# Altium schematic sheet SolarSenseBoard.SchDoc(SolarSenseBoard)
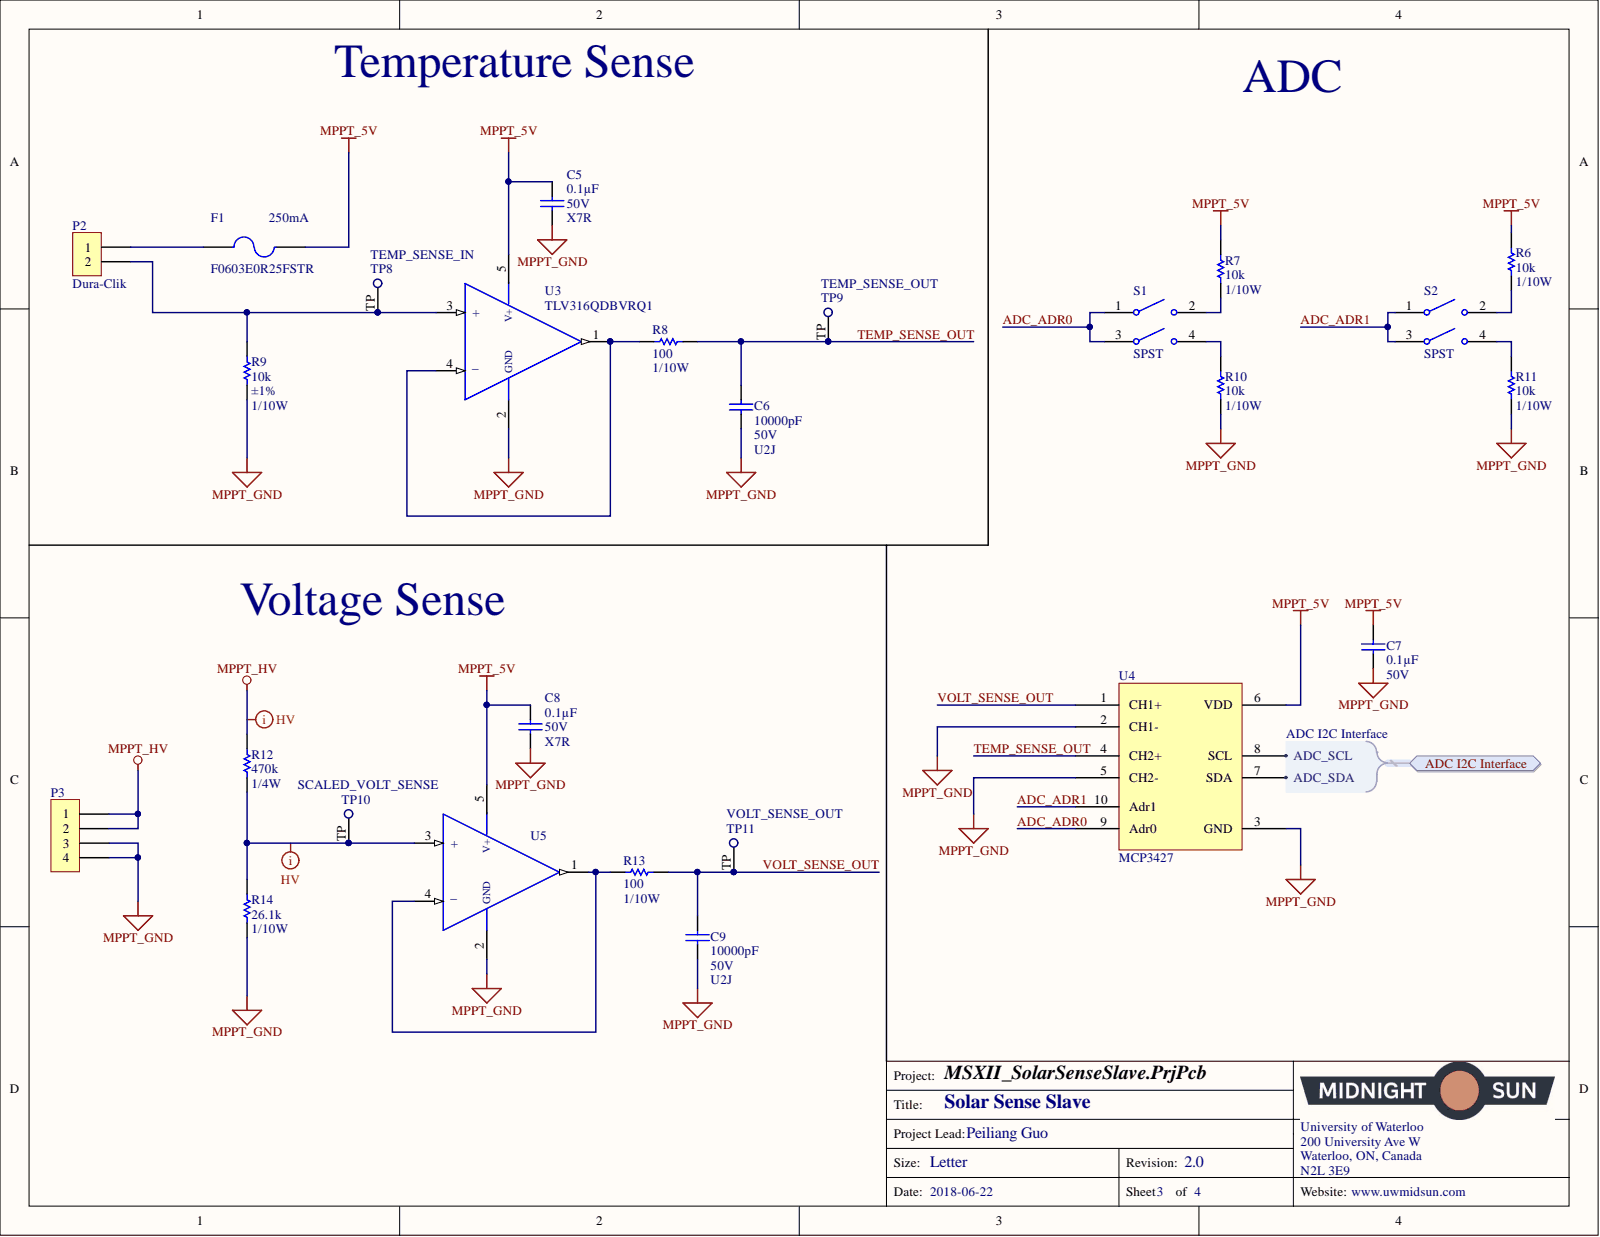
[diagram p0: SolarSenseBoard.SchDoc]

## Parts
C5  Voltage: 50V  Temperature Coefficient: X7R  Capacitance: 0.1µF  [CAP, 0603]  (hidden comment: CAP CER 0.1UF 50V 10% X7R 0603)
C6  Voltage: 50V  Temperature Coefficient: U2J  Capacitance: 10000pF  [CAP, 0603]  (hidden comment: CAP CER 10nF 50V 5% X7R 0603)
C7  Voltage: 50V  Capacitance: 0.1µF  [CAP, 0603]  (hidden comment: CAP CER 0.1UF 50V 10% X7R 0603)
C8  Voltage: 50V  Temperature Coefficient: X7R  Capacitance: 0.1µF  [CAP, 0603]  (hidden comment: CAP CER 0.1UF 50V 10% X7R 0603)
C9  Voltage: 50V  Temperature Coefficient: U2J  Capacitance: 10000pF  [CAP, 0603]  (hidden comment: CAP CER 10nF 50V 5% X7R 0603)
F1  Manufacturer Part Number: F0603E0R25FSTR  Fuse Current: 250mA  [RES, 0603]  (hidden comment: FUSE 250MA 32VDC 0603)
P2  Dura-Clik  [CONN, 2POS Dura-Click Vertical]
P3  CONN 4POS MX150L  [CONN, 4POS MX150L]  (value not drawn)
R6  Resistance: 10k  Power: 1/10W  [RES, 0603]  (hidden comment: RES 10K OHM 1% 1/10W 0603)
R7  Resistance: 10k  Power: 1/10W  [RES, 0603]  (hidden comment: RES 10K OHM 1% 1/10W 0603)
R8  Power: 1/10W  [RES, 0603]  (hidden comment: RES 100 OHM 1% 1/10W 0603)
R9  Tolerance: ±1%  Resistance: 10k  Power: 1/10W  [RES, 0603]  (hidden comment: RES 10K OHM 1% 1/10W 0603)
R10  Resistance: 10k  Power: 1/10W  [RES, 0603]  (hidden comment: RES 10K OHM 1% 1/10W 0603)
R11  Resistance: 10k  Power: 1/10W  [RES, 0603]  (hidden comment: RES 10K OHM 1% 1/10W 0603)
R12  Resistance: 470k  Power: 1/4W  [RES, 0603]  (hidden comment: RES 470K OHM 1% 1/4W 0603)
R13  Power: 1/10W  [RES, 0603]  (hidden comment: RES 100 OHM 1% 1/10W 0603)
R14  Resistance: 26.1k  Power: 1/10W  [RES, 0603]  (hidden comment: RES 26.1K OHM 1% 1/10W 0603)
S1  SPST  [SW SPST 2POS DIP 0.1A 20V]
S2  SPST  [SW SPST 2POS DIP 0.1A 20V]
TP8  TEMP_SENSE_IN  [Test Point]  (no BOM)
TP9  TEMP_SENSE_OUT  [Test Point]
TP10  SCALED_VOLT_SENSE  [Test Point]  (no BOM)
TP11  VOLT_SENSE_OUT  [Test Point]
U3  Manufacturer Part Number 1: TLV316QDBVRQ1  [IC OP AMP GP RR 10MHZ SOT-23-5]  (hidden comment: IC OP AMP GEN PURPOSE RR 10MHZ SOT-23-5)
U4  Base Part Number: MCP3427  [IC ADC MCP3427]  (hidden comment: IC ADC MCP3427 10MSOP)
U5  IC OP AMP GEN PURPOSE RR 10MHZ SOT-23-5  [IC OP AMP GP RR 10MHZ SOT-23-5]  (value not drawn)

## Ports
ADC I2C Interface, ADC I2C Interface.ADC_SCL, ADC I2C Interface.ADC_SDA

## Nets
MPPT_5V: C5.1 C7.1 C8.1 F1.2 R6.1 R7.1 U3.5 U4.6(VDD) U5.5
MPPT_GND: C5.2 C6.2 C7.2 C8.2 C9.2 P3.3 P3.4 R9.2 R10.2 R11.2 R14.2 U3.2 U4.2(CH1-) U4.3(GND) U4.5(CH2-) U5.2
MPPT_HV: P3.1 P3.2 R12.1
ADC_ADR0: S1.1 S1.3 U4.9(Adr0)
ADC_ADR1: S2.1 S2.3 U4.10(Adr1)
TEMP_SENSE_OUT: C6.1 R8.2 TP9.TP U4.4(CH2+)
VOLT_SENSE_OUT: C9.1 R13.2 TP11.TP U4.1(CH1+)
NetF1_1: F1.1 P2.1
NetP2_2: P2.2 R9.1 TP8.TP U3.3(+)
NetR6_2: R6.2 S2.2
NetR7_2: R7.2 S1.2
NetR8_1: R8.1 U3.1 U3.4(_)
NetR10_1: R10.1 S1.4
NetR11_1: R11.1 S2.4
NetR12_2: R12.2 R14.1 TP10.TP U5.3(+)
NetR13_1: R13.1 U5.1 U5.4
NetU4_7: U4.7(SDA) ; port ADC I2C Interface
NetU4_8: U4.8(SCL) ; port ADC I2C Interface

## Library data of the parts
C5 C7 C8 = CAP CER 0.1UF 50V 10% X7R 0603: 0.10µF ±10% 50V Ceramic Capacitor X7R 0603 (1608 Metric); Manufacturer Part Number 1: 06035C104KAT2A; Manufacturer 1: AVX Corporation; Tolerance: ±10%; Thickness (Max): 0.035" (0.90mm); Supplier Part Number 1: 478-5052-1-ND; Supplier 1: Digi-Key; Size / Dimension: 0.063" L x 0.032" W (1.60mm x 0.81mm); Ratings: -; Part Status: Active; Packaging: Cut Tape (CT); Package / Case: 0603 (1608 Metric); Operating Temperature: -55°C ~ 125°C; Mounting Type: Surface Mount, MLCC; Lead Style: -; Lead Spacing: -; Height - Seated (Max): -; ComponentLink1URL: http://datasheets.avx.com/X7RDielectric.pdf; ComponentLink1Description: http://datasheets.avx.com/X7RDielectric.pdf; Component Kind: Standard; Library Name: Schematic Diagrams.SchLib
  C7: Temperature Coefficient: X7R
C6 C9 = CAP CER 10nF 50V 5% X7R 0603: 10000pF ±5% 50V Ceramic Capacitor U2J 0603 (1608 Metric); Manufacturer Part Number 1: C0603C103J5JAC7867; Manufacturer 1: KEMET; Tolerance: ±5%; Thickness (Max): 0.034" (0.87mm); Supplier Part Number 1: 399-13384-1-ND; Supplier 1: Digi-Key; Size / Dimension: 0.063" L x 0.032" W (1.60mm x 0.80mm); Ratings: -; Part Status: Active; Packaging: Cut Tape (CT); Package / Case: 0603 (1608 Metric); Operating Temperature: -55°C ~ 125°C; Mounting Type: Surface Mount, MLCC; Lead Style: -; Lead Spacing: -; Height - Seated (Max): -; Failure Rate: -; ComponentLink1URL: http://www.kemet.com/docfinder?Partnumber=C0603C103J5JAC7867; ComponentLink1Description: http://www.kemet.com/docfinder?Partnumber=C0603C103J5JAC7867; Component Kind: Standard; Library Name: Schematic Diagrams.SchLib
F1 = FUSE 250MA 32VDC 0603: Manufacturer: Kyocera AVX; Voltage Rating (DC): 32V; Resistance: 650mR; Fuse Type: Board Mount (Cartridge Style Excluded); Approvals: UL; Width: 0.81mm; Voltage Rating (AC): 32V; Voltage Rating: 32V; Terminal Material: Nickel; Supplier Part Number 1: 478-2858-1-ND; Supplier 1: Digi-Key; Stock 1: 48569; REACH SVHC: No SVHC; Radiation Hardening: No; Pricing 1: 1=0.41, 5=0.394, 10=0.381, 50=0.3206, 100=0.2662, 250=0.242, 500=0.2057, 1000=0.1815 (USD); Packaging: Tape and Reel; Package Quantity: 1; Number of Pins: 2; Mount: Surface Mount; Min Operating Temperature: -55°C; Max Voltage Rating (DC): 32V; Max Operating Temperature: 125°C; Length: 1.6mm; Lead Free: Lead Free; Height: 0.63mm; Depth: 0.81mm; DC Cold Resistance: 650mR; Current Rating: 250mA; Contact Plating: Tin,Matte; Composition: Thin Film; ComponentLink5URL: https://datasheet.ciiva.com/301/accug2-301327.pdf; ComponentLink5Description: https://datasheet.ciiva.com/301/accug2-301327.pdf; ComponentLink4URL: https://datasheet.ciiva.com/28473/1819437-28473548.pdf; ComponentLink4Description: https://datasheet.ciiva.com/28473/1819437-28473548.pdf; ComponentLink3URL: https://datasheet.ciiva.com/7650/accugrd-7650774.pdf; ComponentLink3Description: https://datasheet.ciiva.com/7650/accugrd-7650774.pdf; ComponentLink2URL: https://datasheet.ciiva.com/301/accug2-301329.pdf; ComponentLink2Description: https://datasheet.ciiva.com/301/accug2-301329.pdf; ComponentLink1URL: https://datasheet.ciiva.com/301/accug2-301328.pdf; ComponentLink1Description: https://datasheet.ciiva.com/301/accug2-301328.pdf; Component Kind: Standard; Case Code (Metric): 1608; Case Code (Imperial): 0603; Case/Package: 0603; Library Name: Schematic Diagrams.SchLib
P2 = CONN 2POS DURA-CLIK 0.079" VERT: 2 Positions Header, Shrouded Connector 0.079" (2.00mm) Surface Mount, Vertical Tin; Manufacturer Part Number: 5600200220; Manufacturer: Molex, LLC; Style: Board to Cable/Wire; Shrouding: Shrouded - 4 Wall; Number of Positions Loaded: All; Number of Positions: 2; Mated Stacking Heights: 9.3mm; Voltage Rating: -; Termination: Solder; Supplier Part Number 1: WM10862CT-ND; Supplier 1: Digi-Key; Stock 1: 11912; Row Spacing - Mating: -; RoHS: RoHS Compliant; Pricing 1: 1=1.16, 10=1.012, 25=0.952, 50=0.9122, 100=0.8727, 250=0.79336 (CAD); Pitch - Mating: 0.079" (2.00mm); Part Status: Active; Packaging: Cut Tape (CT); Overall Contact Length: -; Operating Temperature: -; Number of Rows: 1; Mounting Type: Surface Mount; Material Flammability Rating: -; Insulation Material: Polyamide (PA), Nylon; Insulation Height: 0.315" (8.00mm); Insulation Color: Natural; Ingress Protection: -; Features: Solder Retention; Fastening Type: Latch Lock; Current Rating: -; Contact Type: Male Pin; Contact Shape: Square; Contact Material: Copper Alloy; Contact Length - Post: -; Contact Length - Mating: -; Contact Finish Thickness - Post: -; Contact Finish Thickness - Mating: -; Contact Finish - Post: Tin; Contact Finish - Mating: Tin; Connector Type: Header; ComponentLink1URL: http://www.molex.com/pdm_docs/sd/5600200220_sd.pdf; ComponentLink1Description: http://www.molex.com/pdm_docs/sd/5600200220_sd.pdf; Component Kind: Standard; Category: Connectors, Interconnects; Applications: Automotive, Industrial; Library Name: Schematic Diagrams.SchLib
P3 = CONN 4POS MX150L: Connector Header Panel Mount, Through Hole, Right Angle 4 position 0.230" (5.84mm); Component Kind: Standard; Library Name: Schematic Diagrams.SchLib
R6 R7 R9-R11 = RES 10K OHM 1% 1/10W 0603: RES SMD 10K OHM 1% 1/10W 0603; Manufacturer Part Number 1: RC0603FR-0710KL; Manufacturer 1: Yageo; Temperature Coefficient: ±100ppm/°C; Supplier Part Number 1: 311-10.0KHRCT-ND; Supplier Device Package: 0603; Supplier 1: Digi-Key; Size / Dimension: 0.063" L x 0.031" W (1.60mm x 0.80mm); Part Status: Active; Packaging: Cut Tape (CT); Package / Case: 0603 (1608 Metric); Operating Temperature: -55°C ~ 155°C; Height - Seated (Max): 0.022" (0.55mm); Failure Rate: -; Composition: Thick Film; ComponentLink1URL: http://www.yageo.com/documents/recent/PYu-RC_Group_51_RoHS_L_7.pdf; ComponentLink1Description: http://www.yageo.com/documents/recent/PYu-RC_Group_51_RoHS_L_7.pdf; Component Kind: Standard; Library Name: Schematic Diagrams.SchLib
  R6 R7 R10 R11: Tolerance: ±1%
R8 R13 = RES 100 OHM 1% 1/10W 0603: 100 Ohm ±1% 0.1W, 1/10W Chip Resistor 0603 (1608 Metric) Moisture Resistant Thick Film; Manufacturer Part Number 1: RC0603FR-07100RL; Manufacturer 1: Yageo; Temperature Coefficient: ±100ppm/°C; Resistance: 100; Tolerance: ±1%; Supplier Part Number 1: 311-100HRCT-ND; Supplier Device Package: 0603; Supplier 1: Digi-Key; Size / Dimension: 0.063" L x 0.031" W (1.60mm x 0.80mm); Part Status: Active; Packaging: Cut Tape (CT); Package / Case: 0603 (1608 Metric); Operating Temperature: -55°C ~ 155°C; Height - Seated (Max): 0.022" (0.55mm); Failure Rate: -; Composition: Thick Film; ComponentLink1URL: http://www.yageo.com/documents/recent/PYu-RC_Group_51_RoHS_L_7.pdf; ComponentLink1Description: http://www.yageo.com/documents/recent/PYu-RC_Group_51_RoHS_L_7.pdf; Component Kind: Standard; Library Name: Schematic Diagrams.SchLib
R12 = RES 470K OHM 1% 1/4W 0603: RES SMD 470K OHM 1% 1/4W 0603; Manufacturer Part Number 1: ERJ-PA3F4703V; Manufacturer 1: Panasonic Electronic Components; Temperature Coefficient: ±100ppm/°C; Tolerance: ±1%; Supplier Part Number 1: P470KBYCT-ND; Supplier Device Package: 0603; Supplier 1: Digi-Key; Size / Dimension: 0.063" L x 0.031" W (1.60mm x 0.80mm); Part Status: Active; Packaging: Cut Tape (CT); Package / Case: 0603 (1608 Metric); Operating Temperature: -55°C ~ 155°C; Height - Seated (Max): 0.022" (0.55mm); Failure Rate: -; Composition: Thick Film; ComponentLink1URL: https://industrial.panasonic.com/cdbs/www-data/pdf/RDO0000/AOA0000C291.pdf; ComponentLink1Description: https://industrial.panasonic.com/cdbs/www-data/pdf/RDO0000/AOA0000C291.pdf; Component Kind: Standard; Library Name: Schematic Diagrams.SchLib
R14 = RES 26.1K OHM 1% 1/10W 0603: RES SMD 26.1K OHM 1% 1/10W 0603; Manufacturer Part Number 1: RC0603FR-0726K1L; Manufacturer 1: Yageo; Temperature Coefficient: ±100ppm/°C; Tolerance: ±1%; Supplier Part Number 1: 311-26.1KHRCT-ND; Supplier Device Package: 0603; Supplier 1: Digi-Key; Size / Dimension: 0.063" L x 0.031" W (1.60mm x 0.80mm); Part Status: Active; Packaging: Cut Tape (CT); Package / Case: 0603 (1608 Metric); Operating Temperature: -55°C ~ 155°C; Height - Seated (Max): 0.022" (0.55mm); Failure Rate: -; Composition: Thick Film; ComponentLink1URL: http://www.yageo.com/documents/recent/PYu-RC_Group_51_RoHS_L_7.pdf; ComponentLink1Description: http://www.yageo.com/documents/recent/PYu-RC_Group_51_RoHS_L_7.pdf; Component Kind: Standard; Library Name: Schematic Diagrams.SchLib
S1 S2 = SW SPST 2POS DIP 0.1A 20V: SWITCH SLIDE DIP SPST 100MA 20V; Manufacturer Part Number: 219-2MST; Manufacturer: CTS Electrocomponents; Washable: Yes; Voltage Rating: 20VDC; Pitch: 0.100" (2.54mm), Full; Electrical Life: 2,000 Cycles; Actuator Type: Slide (Standard); Actuator Level: Raised; Termination Style: Gull Wing; Supplier Part Number 1: CT2192MST-ND; Supplier 1: Digi-Key; Stock 1: 444; RoHS: RoHS Compliant; Pricing 1: 1=0.84, 10=0.818, 25=0.7708, 50=0.7234, 100=0.6921, 250=0.66056, 500=0.59762, 1000=0.55044, 5000=0.44822 (CAD); Part Status: Active; Packaging: Tube; Operating Temperature: -55°C ~ 85°C; Mounting Type: Surface Mount; Mechanical Life: -; Length: 0.258" (6.55mm); Height Above Board: 0.170" (4.33mm); Features: Epoxy Sealed Terminals, Tape Seal; Current Rating: 100mA; Contact Material: Beryllium Copper; Contact Finish: Gold; ComponentLink1URL: https://www.ctscorp.com/wp-content/uploads/219.pdf; ComponentLink1Description: https://www.ctscorp.com/wp-content/uploads/219.pdf; Component Kind: Standard; Circuit: SPST; Category: Switches; Body Material: -; Library Name: Schematic Diagrams.SchLib
TP8-TP11 = Test Point: Library Name: Schematic Diagrams.SchLib
  TP9 TP11: Component Kind: Standard
U3 = IC OP AMP GEN PURPOSE RR 10MHZ SOT-23-5: General Purpose Amplifier 1 Circuit Rail-to-Rail SOT-23-5; Manufacturer 1: Texas Instruments; Voltage - Supply, Single/Dual (±): 1.8 V ~ 5.5 V, ±0.9 V ~ 2.75 V; Slew Rate: 6 V/µs; Number of Circuits: 1; Description 1: IC OP AMP GP RR 10MHZ 5-SOT-23; Current - Supply: 400µA; Current - Output / Channel: 50mA; Supplier Part Number 1: 296-45323-1-ND; Supplier Device Package: SOT-23-5; Supplier 1: Digi-Key; Stock 1: 1747; RoHS: RoHS Compliant; Pricing 1: 1=1.33, 10=1.185, 100=0.9234, 500=0.76276, 1000=0.60218 (CAD); Part Status: Active; Packaging: Cut Tape (CT); Package / Case: SC-74A, SOT-753; Output Type: Rail-to-Rail; Operating Temperature: -40°C ~ 125°C; Mounting Type: Surface Mount; ComponentLink1URL: http://www.ti.com/lit/ds/symlink/tlv316-q1.pdf; ComponentLink1Description: http://www.ti.com/lit/ds/symlink/tlv316-q1.pdf; Component Kind: Standard; Category: Integrated Circuits (ICs); Amplifier Type: General Purpose; -3db Bandwidth: -; Library Name: Schematic Diagrams.SchLib
U4 = IC ADC MCP3427 10MSOP: 16 Bit Analog to Digital Converter 2 Input 1 Sigma-Delta 10-MSOP; Manufacturer Part Number: MCP3427-E/UN; Manufacturer: Microchip Technology; Voltage - Supply, Digital: 2.7 V ~ 5.5 V; Sampling Rate (Per Second): 15; Reference Type: Internal; Number of Inputs: 2; Number of Bits: 16; Input Type: Differential; Data Interface: I²C; Configuration: MUX-PGA-ADC; Voltage - Supply, Analog: 2.7 V ~ 5.5 V; Supplier Part Number 1: MCP3427-E/UN-ND; Supplier Device Package: 10-MSOP; Supplier 1: Digi-Key; Stock 1: 3001; RoHS: RoHS Compliant; Ratio - S/H: -; Pricing 1: 1=4.32, 25=3.6064, 100=3.2704 (CAD); Part Status: Active; Packaging: Tube; Package / Case: 10-TFSOP, 10-MSOP (0.118", 3.00mm Width); Operating Temperature: -40°C ~ 125°C; Features: PGA, Selectable Address; ComponentLink1URL: http://www.microchip.com/mymicrochip/filehandler.aspx?ddocname=en546216; ComponentLink1Description: http://www.microchip.com/mymicrochip/filehandler.aspx?ddocname=en546216; Component Kind: Standard; Category: Integrated Circuits (ICs); Architecture: Sigma-Delta; Library Name: Schematic Diagrams.SchLib
U5 = IC OP AMP GEN PURPOSE RR 10MHZ SOT-23-5: General Purpose Amplifier 1 Circuit Rail-to-Rail SOT-23-5; Manufacturer Part Number 1: TLV316QDBVRQ1; Manufacturer 1: Texas Instruments; Voltage - Supply, Single/Dual (±): 1.8 V ~ 5.5 V, ±0.9 V ~ 2.75 V; Slew Rate: 6 V/µs; Number of Circuits: 1; Description 1: IC OP AMP GP RR 10MHZ 5-SOT-23; Current - Supply: 400µA; Current - Output / Channel: 50mA; Supplier Part Number 1: 296-45323-1-ND; Supplier Device Package: SOT-23-5; Supplier 1: Digi-Key; Stock 1: 1747; RoHS: RoHS Compliant; Pricing 1: 1=1.33, 10=1.185, 100=0.9234, 500=0.76276, 1000=0.60218 (CAD); Part Status: Active; Packaging: Cut Tape (CT); Package / Case: SC-74A, SOT-753; Output Type: Rail-to-Rail; Operating Temperature: -40°C ~ 125°C; Mounting Type: Surface Mount; ComponentLink1URL: http://www.ti.com/lit/ds/symlink/tlv316-q1.pdf; ComponentLink1Description: http://www.ti.com/lit/ds/symlink/tlv316-q1.pdf; Component Kind: Standard; Category: Integrated Circuits (ICs); Amplifier Type: General Purpose; -3db Bandwidth: -; Library Name: Schematic Diagrams.SchLib
